# Altium schematic sheet H-9220 Backplane Board - DUT Bd7-8 (REV07).SchDoc
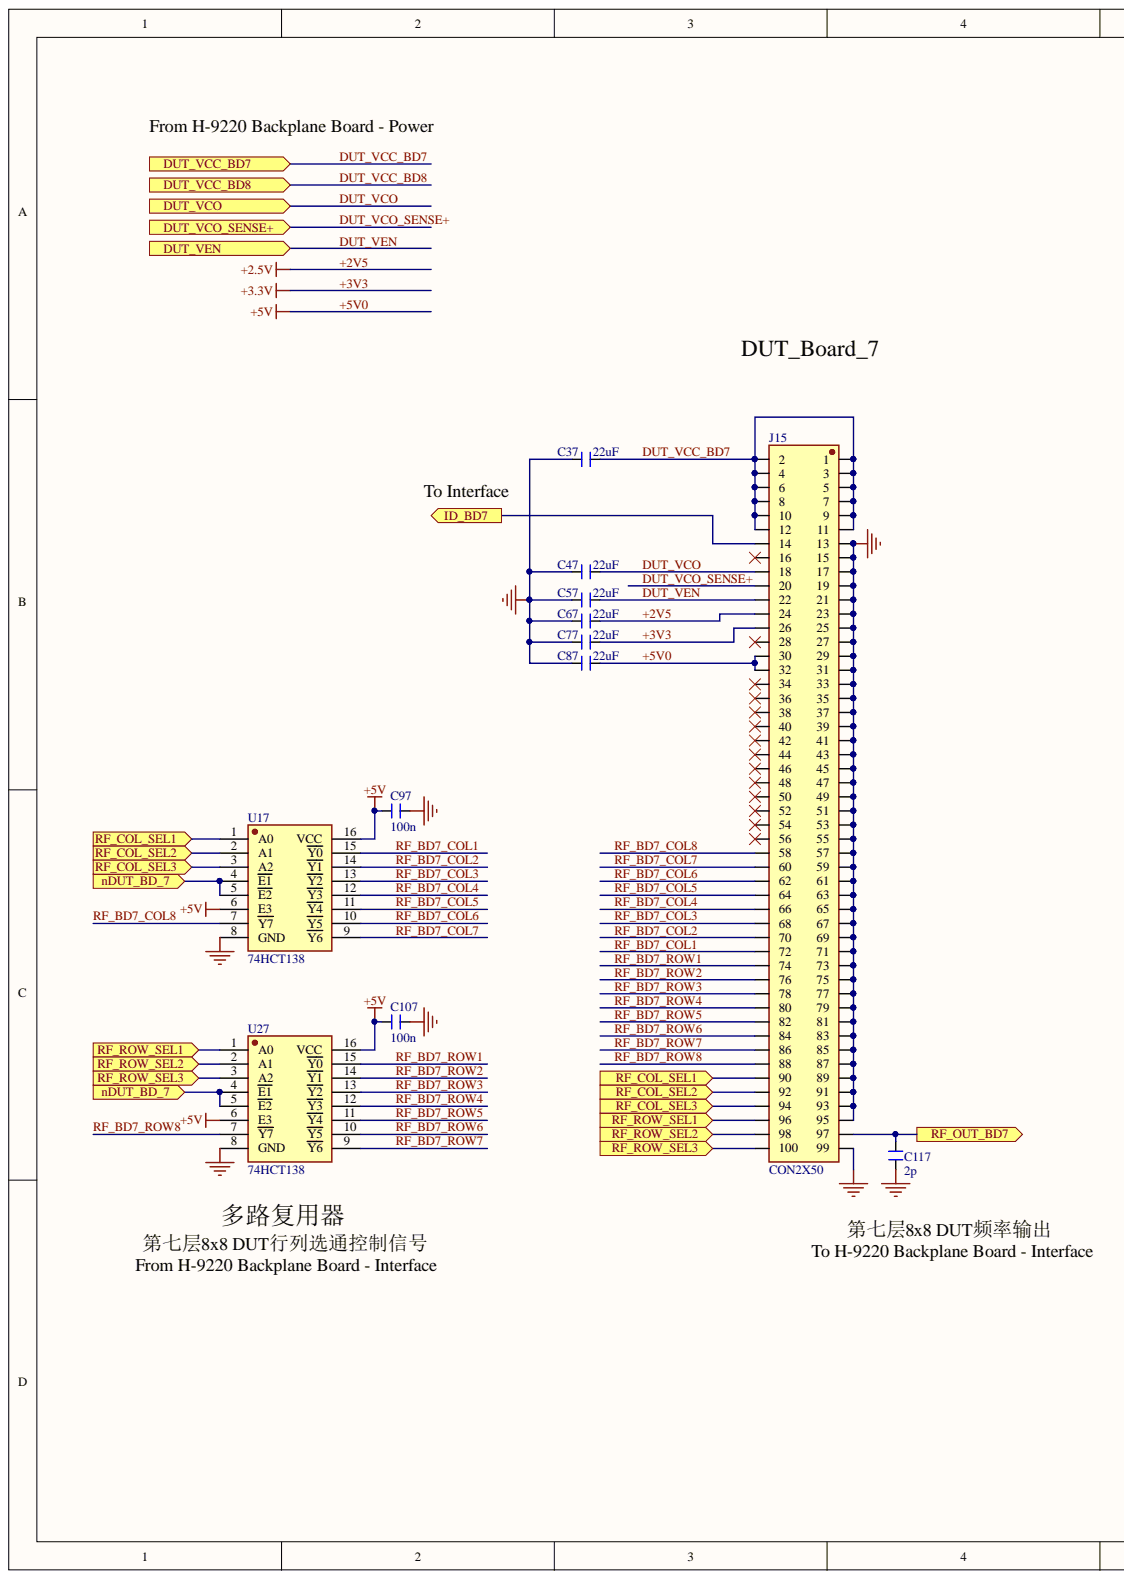
[diagram p0: H-9220 Backplane Board - DUT Bd7-8 (REV07).SchDoc, left]
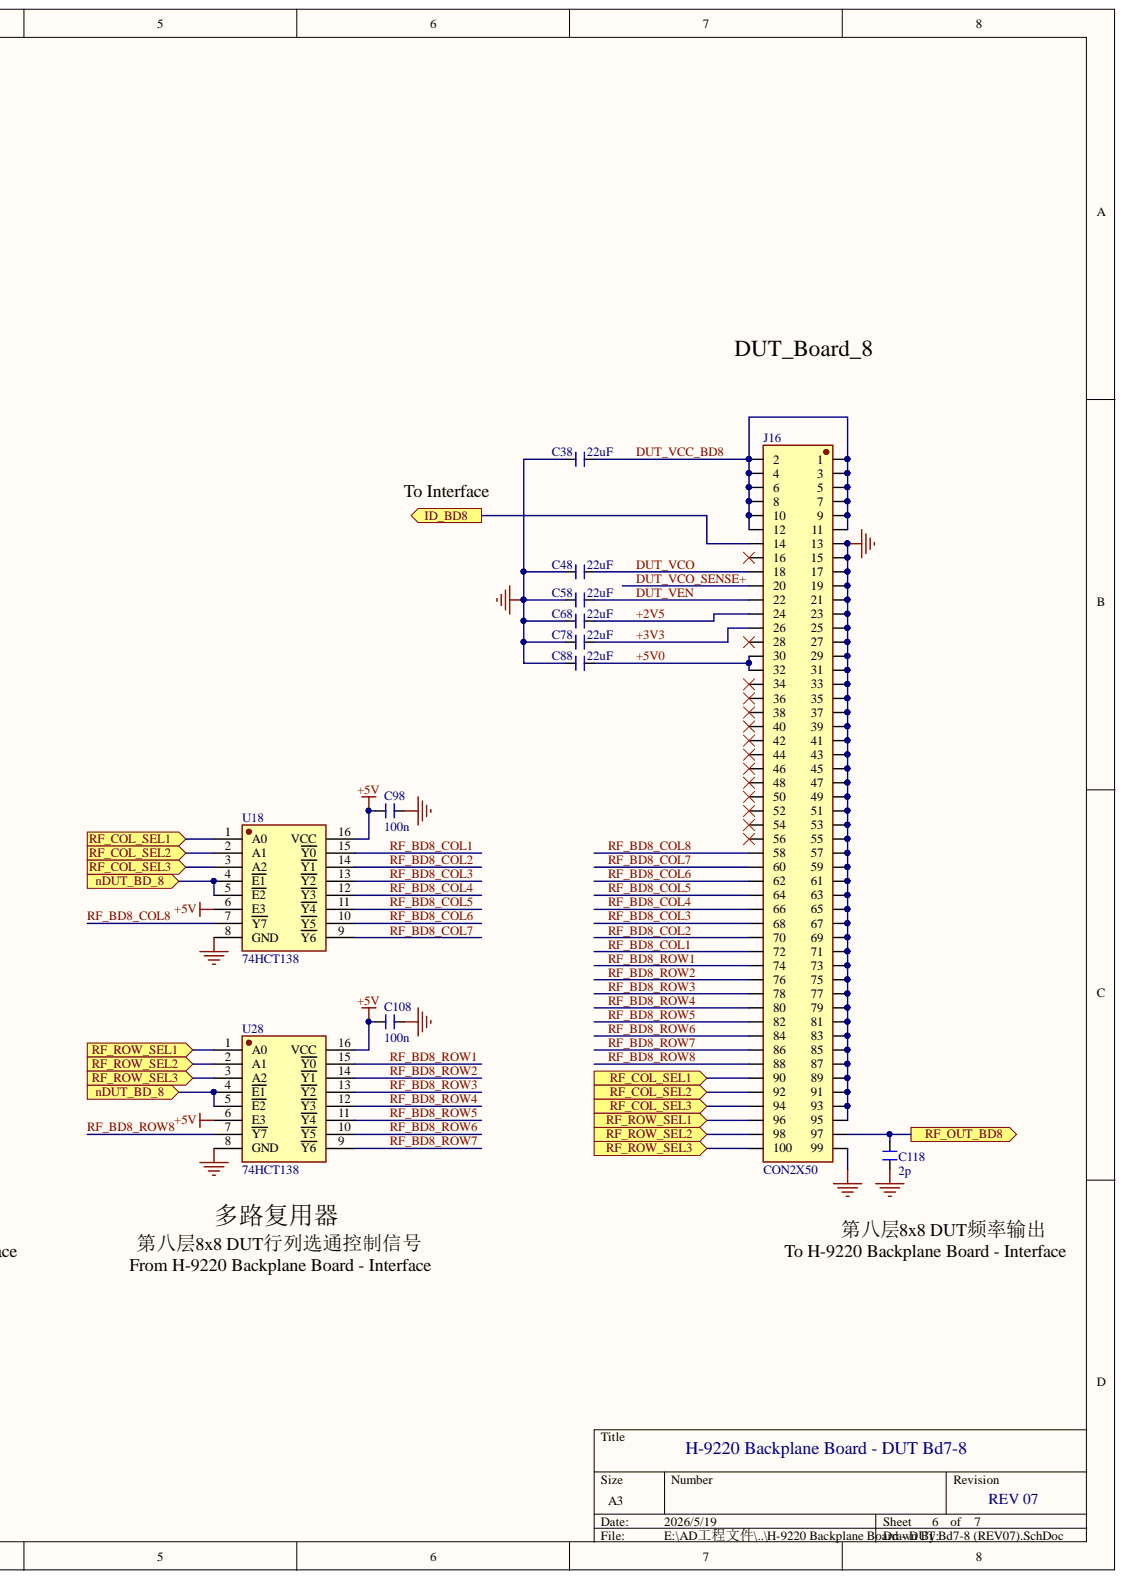
[diagram p1: H-9220 Backplane Board - DUT Bd7-8 (REV07).SchDoc, right]

## Parts
C37  22uF  [C-1206]
C38  22uF  [C-1206]
C47  22uF  [C-1206]
C48  22uF  [C-1206]
C57  22uF  [C-1206]
C58  22uF  [C-1206]
C67  22uF  [C-1206]
C68  22uF  [C-1206]
C77  22uF  [C-1206]
C78  22uF  [C-1206]
C87  22uF  [C-1206]
C88  22uF  [C-1206]
C97  100n  [C-0603]
C98  100n  [C-0603]
C107  100n  [C-0603]
C108  100n  [C-0603]
C117  2p  [C-0603]
C118  2p  [C-0603]
J15  CON2X50  [SFML-150-01-S-D-LC]
J16  CON2X50  [SFML-150-01-S-D-LC]
U17  74HCT138  [SOIC-16 (BODY=3.9MM)]
U18  74HCT138  [SOIC-16 (BODY=3.9MM)]
U27  74HCT138  [SOIC-16 (BODY=3.9MM)]
U28  74HCT138  [SOIC-16 (BODY=3.9MM)]

## Ports
DUT_VCC_BD7, DUT_VCC_BD8, DUT_VCO, DUT_VCO_SENSE+, DUT_VEN, ID_BD7, ID_BD8, nDUT_BD_7, nDUT_BD_8, RF_COL_SEL1, RF_COL_SEL2, RF_COL_SEL3, RF_OUT_BD7, RF_OUT_BD8, RF_ROW_SEL1, RF_ROW_SEL2, RF_ROW_SEL3

## Nets
GND: C37.2 C38.2 C47.2 C48.2 C57.2 C58.2 C67.2 C68.2 C77.2 C78.2 C87.2 C88.2 C97.2 C98.2 C107.2 C108.2 C117.2 C118.2 J15.13 J15.15 J15.17 J15.19 J15.21 J15.23 J15.25 J15.27 J15.29 J15.31 J15.33 J15.35 J15.37 J15.39 J15.41 J15.43 J15.45 J15.47 J15.49 J15.51 J15.53 J15.55 J15.57 J15.59 J15.61 J15.63 J15.65 J15.67 J15.69 J15.71 J15.73 J15.75 J15.77 J15.79 J15.81 J15.83 J15.85 J15.87 J15.89 J15.91 J15.93 J15.95 J15.99 J16.13 J16.15 J16.17 J16.19 J16.21 J16.23 J16.25 J16.27 J16.29 J16.31 J16.33 J16.35 J16.37 J16.39 J16.41 J16.43 J16.45 J16.47 J16.49 J16.51 J16.53 J16.55 J16.57 J16.59 J16.61 J16.63 J16.65 J16.67 J16.69 J16.71 J16.73 J16.75 J16.77 J16.79 J16.81 J16.83 J16.85 J16.87 J16.89 J16.91 J16.93 J16.95 J16.99 U17.8(GND) U18.8(GND) U27.8(GND) U28.8(GND)
+2V5: C67.1 C68.1 J15.24 J16.24
+3V3: C77.1 C78.1 J15.26 J16.26
+5V0: C87.1 C88.1 C97.1 C98.1 C107.1 C108.1 J15.30 J15.32 J16.30 J16.32 U17.6(E3) U17.16(VCC) U18.6(E3) U18.16(VCC) U27.6(E3) U27.16(VCC) U28.6(E3) U28.16(VCC)
DUT_VCC_BD7: C37.1 J15.1 J15.2 J15.3 J15.4 J15.5 J15.6 J15.7 J15.8 J15.9 J15.10 J15.11 J15.12 ; port DUT_VCC_BD7
DUT_VCC_BD8: C38.1 J16.1 J16.2 J16.3 J16.4 J16.5 J16.6 J16.7 J16.8 J16.9 J16.10 J16.11 J16.12 ; port DUT_VCC_BD8
DUT_VCO: C47.1 C48.1 J15.18 J16.18 ; port DUT_VCO
DUT_VCO_SENSE+: J15.20 J16.20 ; port DUT_VCO_SENSE+
DUT_VEN: C57.1 C58.1 J15.22 J16.22 ; port DUT_VEN
ID_BD7: J15.14 ; port ID_BD7
ID_BD8: J16.14 ; port ID_BD8
nDUT_BD_7: U17.4(E1) U17.5(E2) U27.4(E1) U27.5(E2) ; port nDUT_BD_7
nDUT_BD_8: U18.4(E1) U18.5(E2) U28.4(E1) U28.5(E2) ; port nDUT_BD_8
RF_BD7_COL1: J15.72 U17.15(Y0)
RF_BD7_COL2: J15.70 U17.14(Y1)
RF_BD7_COL3: J15.68 U17.13(Y2)
RF_BD7_COL4: J15.66 U17.12(Y3)
RF_BD7_COL5: J15.64 U17.11(Y4)
RF_BD7_COL6: J15.62 U17.10(Y5)
RF_BD7_COL7: J15.60 U17.9(Y6)
RF_BD7_COL8: J15.58 U17.7(Y7)
RF_BD7_ROW1: J15.74 U27.15(Y0)
RF_BD7_ROW2: J15.76 U27.14(Y1)
RF_BD7_ROW3: J15.78 U27.13(Y2)
RF_BD7_ROW4: J15.80 U27.12(Y3)
RF_BD7_ROW5: J15.82 U27.11(Y4)
RF_BD7_ROW6: J15.84 U27.10(Y5)
RF_BD7_ROW7: J15.86 U27.9(Y6)
RF_BD7_ROW8: J15.88 U27.7(Y7)
RF_BD8_COL1: J16.72 U18.15(Y0)
RF_BD8_COL2: J16.70 U18.14(Y1)
RF_BD8_COL3: J16.68 U18.13(Y2)
RF_BD8_COL4: J16.66 U18.12(Y3)
RF_BD8_COL5: J16.64 U18.11(Y4)
RF_BD8_COL6: J16.62 U18.10(Y5)
RF_BD8_COL7: J16.60 U18.9(Y6)
RF_BD8_COL8: J16.58 U18.7(Y7)
RF_BD8_ROW1: J16.74 U28.15(Y0)
RF_BD8_ROW2: J16.76 U28.14(Y1)
RF_BD8_ROW3: J16.78 U28.13(Y2)
RF_BD8_ROW4: J16.80 U28.12(Y3)
RF_BD8_ROW5: J16.82 U28.11(Y4)
RF_BD8_ROW6: J16.84 U28.10(Y5)
RF_BD8_ROW7: J16.86 U28.9(Y6)
RF_BD8_ROW8: J16.88 U28.7(Y7)
RF_COL_SEL1: J15.90 J16.90 U17.1(A0) U18.1(A0) ; port RF_COL_SEL1
RF_COL_SEL2: J15.92 J16.92 U17.2(A1) U18.2(A1) ; port RF_COL_SEL2
RF_COL_SEL3: J15.94 J16.94 U17.3(A2) U18.3(A2) ; port RF_COL_SEL3
RF_OUT_BD7: C117.1 J15.97 ; port RF_OUT_BD7
RF_OUT_BD8: C118.1 J16.97 ; port RF_OUT_BD8
RF_ROW_SEL1: J15.96 J16.96 U27.1(A0) U28.1(A0) ; port RF_ROW_SEL1
RF_ROW_SEL2: J15.98 J16.98 U27.2(A1) U28.2(A1) ; port RF_ROW_SEL2
RF_ROW_SEL3: J15.100 J16.100 U27.3(A2) U28.3(A2) ; port RF_ROW_SEL3

Not connected: J15 16 28 34 36 38 40 42 44 46 48 50 52 54 56; J16 16 28 34 36 38 40 42 44 46 48 50 52 54 56

## Library data of the parts
C37 C38 C47 C48 C57 C58 C67 C68 C77 C78 C87 C88 C97 C98 C107 C108 C117 C118 = CAP: Component Kind: Standard; Library Name: HW SCH Lib.SCHLIB
J15 J16 = CON-2x50P: Component Kind: Standard; Library Name: HW SCH Lib.SCHLIB
U17 U18 U27 U28 = 74HCT138: Component Kind: Standard; Library Name: HW SCH Lib.SCHLIB
